# Altium schematic sheet PowerSchem.SchDoc(PowerSchem) (continued)
## Library data of the parts (continued)
C42 = CAP: Ceramic Capacitor, Multilayer, Ceramic, 250V, 5% +Tol, 5% -Tol, C0G, 30ppm/Cel TC, 5.6e-05uF, Surface Mount, 0603; Manufacturer: Knowles; Manufacturer Part Number: QSCP251Q560J1GV001T; Case/Package: 0603; Category: Ceramic Capacitors; Component Kind: Standard; ComponentLink1Description: https://datasheet.octopart.com:443/QSCP251Q560J1GV001T-Johanson-datasheet-187816102.pdf?src-supplier=IHS; ComponentLink1URL: https://datasheet.octopart.com:443/QSCP251Q560J1GV001T-Johanson-datasheet-187816102.pdf?src-supplier=IHS; Country of Origin: USA; Dielectric Material: Ceramic; Export Control Classification Number (ECCN) Code: EAR99; Harmonized Tariff Schedule (HTS) Code: 8532.24.00.20; Height: 760µm; Introduction Date: 2022-02-04; Length: 1.57mm; Library Name: Standard.SchLib; Lifecycle Status: Production; Max Operating Temperature: 125°C; Min Operating Temperature: -55°C; Mounting Technology: Surface Mount; Number of Terminals: 2; Pricing: 1=0.28, 10=0.162, 50=0.1176, 100=0.1038, 500=0.08066, 1000=0.07352 (USD); REACH SVHC: Yes; RoHS: Compliant; Stock: 30631; Supplier 1: DigiKey; Supplier Part Number 1: 712-QSCP251Q560J1GV001TCT-ND; Value: 56p; Voltage Rating (DC): 250V; Width: 810µm
C43 = CAP: 0603 C 15NF Ceramic Multilayer Capacitor, 25 V, +125DEGC, C0G Dielectric, +/-5%; Manufacturer: Yageo Group; Manufacturer Part Number: C0603C153J3GACTU; Dissipation Factor: 0.1%; Temperature Coefficient: 30ppm/°C; Tolerance: 5%; Voltage: 25V; Case Code (Imperial): 0603; Case Code (Metric): 1608; Case/Package: 0603; Category: Ceramic Capacitors; China RoHS: Compliant; Component Kind: Standard; ComponentLink1Description: https://datasheet.octopart.com:443/C0603C153J3GACTU-KEMET-datasheet-178132257.pdf?src-supplier=IHS; ComponentLink1URL: https://datasheet.octopart.com:443/C0603C153J3GACTU-KEMET-datasheet-178132257.pdf?src-supplier=IHS; ComponentLink2Description: https://datasheet.octopart.com:443/C0603C153J3GAC7867-KEMET-datasheet-10540709.pdf?src-supplier=IHS; ComponentLink2URL: https://datasheet.octopart.com:443/C0603C153J3GAC7867-KEMET-datasheet-10540709.pdf?src-supplier=IHS; ComponentLink3Description: https://datasheet.octopart.com:443/C0603C153J3GACTU-KEMET-datasheet-137357764.pdf?src-supplier=Upverter; ComponentLink3URL: https://datasheet.octopart.com:443/C0603C153J3GACTU-KEMET-datasheet-137357764.pdf?src-supplier=Upverter; ComponentLink4Description: https://datasheet.octopart.com:443/C0603C153J3GACTU-KEMET-datasheet-68331921.pdf?src-supplier=Farnell; ComponentLink4URL: https://datasheet.octopart.com:443/C0603C153J3GACTU-KEMET-datasheet-68331921.pdf?src-supplier=Farnell; ComponentLink5Description: https://datasheet.octopart.com:443/C0603C153J3GACTU-KEMET-datasheet-102648314.pdf?src-supplier=Farnell; ComponentLink5URL: https://datasheet.octopart.com:443/C0603C153J3GACTU-KEMET-datasheet-102648314.pdf?src-supplier=Farnell; ComponentLink6Description: https://datasheet.octopart.com:443/C0603C153J3GACTU-Kemet-datasheet-5308203.pdf?src-supplier=Farnell; ComponentLink6URL: https://datasheet.octopart.com:443/C0603C153J3GACTU-Kemet-datasheet-5308203.pdf?src-supplier=Farnell; ComponentLink7Description: https://datasheet.octopart.com:443/C0603C153J3GACTU-KEMET-datasheet-176289261.pdf?src-supplier=element14+APAC; ComponentLink7URL: https://datasheet.octopart.com:443/C0603C153J3GACTU-KEMET-datasheet-176289261.pdf?src-supplier=element14+APAC; ComponentLink8Description: https://datasheet.octopart.com:443/C0603C153J3GACTU-KEMET-datasheet-178087916.pdf?src-supplier=element14+APAC; ComponentLink8URL: https://datasheet.octopart.com:443/C0603C153J3GACTU-KEMET-datasheet-178087916.pdf?src-supplier=element14+APAC; ComponentLink9Description: https://datasheet.octopart.com:443/C0603C153J3GACTU-Kemet-datasheet-66093294.pdf?src-supplier=element14+APAC; ComponentLink9URL: https://datasheet.octopart.com:443/C0603C153J3GACTU-Kemet-datasheet-66093294.pdf?src-supplier=element14+APAC; ComponentLink10Description: https://datasheet.octopart.com:443/C0603C153J3GACTU-Kemet-datasheet-66634713.pdf?src-supplier=element14+APAC; ComponentLink10URL: https://datasheet.octopart.com:443/C0603C153J3GACTU-Kemet-datasheet-66634713.pdf?src-supplier=element14+APAC; ComponentLink11Description: https://datasheet.octopart.com:443/C0603C153J3GACTU-KEMET-datasheet-157246123.pdf?src-supplier=Electro+Sonic; ComponentLink11URL: https://datasheet.octopart.com:443/C0603C153J3GACTU-KEMET-datasheet-157246123.pdf?src-supplier=Electro+Sonic; ComponentLink12Description: https://datasheet.octopart.com:443/C0603C153J3GAC7867-KEMET-datasheet-188512010.pdf?src-supplier=ODG+(Origin+Data+Global); ComponentLink12URL: https://datasheet.octopart.com:443/C0603C153J3GAC7867-KEMET-datasheet-188512010.pdf?src-supplier=ODG+(Origin+Data+Global); ComponentLink13Description: https://datasheet.octopart.com:443/C0603C153J3GACTU-KEMET-datasheet-176142193.pdf?src-supplier=Newark; ComponentLink13URL: https://datasheet.octopart.com:443/C0603C153J3GACTU-KEMET-datasheet-176142193.pdf?src-supplier=Newark; ComponentLink14Description: https://datasheet.octopart.com:443/C0603C153J3GACTU-KEMET-datasheet-101575703.pdf?src-supplier=Newark; ComponentLink14URL: https://datasheet.octopart.com:443/C0603C153J3GACTU-KEMET-datasheet-101575703.pdf?src-supplier=Newark; ComponentLink15Description: https://datasheet.octopart.com:443/C0603C153J3GACTU-Kemet-datasheet-62307647.pdf?src-supplier=Newark; ComponentLink15URL: https://datasheet.octopart.com:443/C0603C153J3GACTU-Kemet-datasheet-62307647.pdf?src-supplier=Newark; ComponentLink16Description: https://datasheet.octopart.com:443/C0603C153J3GACTU-KEMET-datasheet-193515620.pdf?src-supplier=Newark; ComponentLink16URL: https://datasheet.octopart.com:443/C0603C153J3GACTU-KEMET-datasheet-193515620.pdf?src-supplier=Newark; ComponentLink17Description: https://datasheet.octopart.com:443/C0603C153J3GACTU-Kemet-datasheet-67830794.pdf?src-supplier=TME; ComponentLink17URL: https://datasheet.octopart.com:443/C0603C153J3GACTU-Kemet-datasheet-67830794.pdf?src-supplier=TME; ComponentLink18Description: https://datasheet.octopart.com/C0603C153J3GACTU-KEMET-datasheet-84169754.pdf; ComponentLink18URL: https://datasheet.octopart.com/C0603C153J3GACTU-KEMET-datasheet-84169754.pdf; ComponentLink19Description: https://datasheet.octopart.com:443/C0603C153J3GACTU-Kemet-datasheet-60243840.pdf?src-supplier=RS+(Formerly+Allied+Electronics); ComponentLink19URL: https://datasheet.octopart.com:443/C0603C153J3GACTU-Kemet-datasheet-60243840.pdf?src-supplier=RS+(Formerly+Allied+Electronics); ComponentLink20Description: http://sigma.octopart.com:80/conflict_mineral_statement/Yageo-company-3.pdf?src-supplier=Compliance+Statements; ComponentLink20URL: http://sigma.octopart.com:80/conflict_mineral_statement/Yageo-company-3.pdf?src-supplier=Compliance+Statements; ComponentLink21Description: http://sigma.octopart.com:80/rohs_statement/Yageo-company-2.pdf?src-supplier=Compliance+Statements; ComponentLink21URL: http://sigma.octopart.com:80/rohs_statement/Yageo-company-2.pdf?src-supplier=Compliance+Statements; Contact Plating: Tin; Country of Origin: Mexico; Depth: 800µm; Dielectric: C0G; Dielectric Material: Ceramic; Export Control Classification Number (ECCN) Code: EAR99; Harmonized Tariff Schedule (HTS) Code: 8532.24.00.20; Height: 800µm; Introduction Date: 2008-06-06; Lead Free: Lead Free; Length: 1.6mm; Library Name: Standard.SchLib; Lifecycle Status: Production; Material: Ceramic; Max Operating Temperature: 125°C; Min Operating Temperature: -55°C; Mounting Technology: Surface Mount; Number of Terminals: 2; Packaging: Cut Tape; Pins: 2; Pricing: 4000=0.087, 8000=0.084 (USD); REACH SVHC: Yes; RoHS: Compliant; Schedule B: 8532240020, 8532240020|8532240020, 8532240020|8532240020|8532240020, 8532240020|8532240020|8532240020|8532240020; Stock: 31000; Supplier 1: Newark; Supplier Part Number 1: C0603C153J3GACTU; Termination: SMD/SMT; Thickness: 863.6µm; Value: 15n; Voltage Rating: 25V; Voltage Rating (DC): 25V; Width: 800µm
C47 C48 = CAP: Multilayer Ceramic Capacitors Mlcc - Smd/Smt 50V 0.01Uf X7R 0603 10%; Manufacturer: Yageo Group; Manufacturer Part Number: C0603C103K5RACTU; Dissipation Factor: 2.5%; Temperature Coefficient: 30ppm/°C; Case Code (Imperial): 0603; Case/Package: 0603; Category: Ceramic Capacitors; China RoHS: Compliant; Component Kind: Standard; ComponentLink1Description: https://datasheet.octopart.com:443/C0603C103K5RACTU-KEMET-datasheet-178445679.pdf?src-supplier=Farnell; ComponentLink1URL: https://datasheet.octopart.com:443/C0603C103K5RACTU-KEMET-datasheet-178445679.pdf?src-supplier=Farnell; ComponentLink2Description: https://datasheet.octopart.com:443/C0603C103K5RACTU-KEMET-datasheet-137357759.pdf?src-supplier=Farnell; ComponentLink2URL: https://datasheet.octopart.com:443/C0603C103K5RACTU-KEMET-datasheet-137357759.pdf?src-supplier=Farnell; ComponentLink3Description: https://datasheet.octopart.com:443/C0603C103K5RACTU-KEMET-datasheet-149521831.pdf?src-supplier=Farnell; ComponentLink3URL: https://datasheet.octopart.com:443/C0603C103K5RACTU-KEMET-datasheet-149521831.pdf?src-supplier=Farnell; ComponentLink4Description: https://datasheet.octopart.com:443/C0603C103K5RAC-TU-Kemet-datasheet-12608295.pdf?src-supplier=Farnell; ComponentLink4URL: https://datasheet.octopart.com:443/C0603C103K5RAC-TU-Kemet-datasheet-12608295.pdf?src-supplier=Farnell; ComponentLink5Description: https://datasheet.octopart.com:443/C0603C103K5RAC-TU-Kemet-datasheet-11992184.pdf?src-supplier=Farnell; ComponentLink5URL: https://datasheet.octopart.com:443/C0603C103K5RAC-TU-Kemet-datasheet-11992184.pdf?src-supplier=Farnell; ComponentLink6Description: https://datasheet.octopart.com:443/C0603C103K5RAC-TU-Kemet-datasheet-10942409.pdf?src-supplier=Farnell; ComponentLink6URL: https://datasheet.octopart.com:443/C0603C103K5RAC-TU-Kemet-datasheet-10942409.pdf?src-supplier=Farnell; ComponentLink7Description: https://datasheet.octopart.com:443/C0603C103K5RAC-TU-Kemet-datasheet-10404442.pdf?src-supplier=Farnell; ComponentLink7URL: https://datasheet.octopart.com:443/C0603C103K5RAC-TU-Kemet-datasheet-10404442.pdf?src-supplier=Farnell; ComponentLink8Description: https://datasheet.octopart.com:443/C0603C103K5RACTU-KEMET-datasheet-101575646.pdf?src-supplier=Farnell; ComponentLink8URL: https://datasheet.octopart.com:443/C0603C103K5RACTU-KEMET-datasheet-101575646.pdf?src-supplier=Farnell; ComponentLink9Description: https://datasheet.octopart.com:443/C0603C103K5RACTU.-Kemet-datasheet-41232427.pdf?src-supplier=Farnell; ComponentLink9URL: https://datasheet.octopart.com:443/C0603C103K5RACTU.-Kemet-datasheet-41232427.pdf?src-supplier=Farnell; ComponentLink10Description: https://datasheet.octopart.com:443/C0603C103K5RACTU-KEMET-datasheet-176142155.pdf?src-supplier=Farnell; ComponentLink10URL: https://datasheet.octopart.com:443/C0603C103K5RACTU-KEMET-datasheet-176142155.pdf?src-supplier=Farnell; ComponentLink11Description: https://datasheet.octopart.com:443/C0603C103K5RAC-TU-Kemet-datasheet-7833651.pdf?src-supplier=Farnell; ComponentLink11URL: https://datasheet.octopart.com:443/C0603C103K5RAC-TU-Kemet-datasheet-7833651.pdf?src-supplier=Farnell; ComponentLink12Description: https://datasheet.octopart.com:443/C0603C103K5RAC-TU-Kemet-datasheet-7601896.pdf?src-supplier=Farnell; ComponentLink12URL: https://datasheet.octopart.com:443/C0603C103K5RAC-TU-Kemet-datasheet-7601896.pdf?src-supplier=Farnell; ComponentLink13Description: https://datasheet.octopart.com:443/C0603C103K5RAC-TU-Kemet-datasheet-5316294.pdf?src-supplier=Farnell; ComponentLink13URL: https://datasheet.octopart.com:443/C0603C103K5RAC-TU-Kemet-datasheet-5316294.pdf?src-supplier=Farnell; ComponentLink14Description: https://datasheet.octopart.com:443/C0603C103K5RAC-TU-Kemet-datasheet-5314837.pdf?src-supplier=Farnell; ComponentLink14URL: https://datasheet.octopart.com:443/C0603C103K5RAC-TU-Kemet-datasheet-5314837.pdf?src-supplier=Farnell; ComponentLink15Description: https://datasheet.octopart.com:443/C0603C103K5RAC-TU-Kemet-datasheet-8092359.pdf?src-supplier=Farnell; ComponentLink15URL: https://datasheet.octopart.com:443/C0603C103K5RAC-TU-Kemet-datasheet-8092359.pdf?src-supplier=Farnell; ComponentLink16Description: https://datasheet.octopart.com:443/C0603C103K5RACTU-Kemet-datasheet-5314449.pdf?src-supplier=Farnell; ComponentLink16URL: https://datasheet.octopart.com:443/C0603C103K5RACTU-Kemet-datasheet-5314449.pdf?src-supplier=Farnell; ComponentLink17Description: https://datasheet.octopart.com:443/C0603C103K5RAC-TU-Kemet-datasheet-5390537.pdf?src-supplier=Farnell; ComponentLink17URL: https://datasheet.octopart.com:443/C0603C103K5RAC-TU-Kemet-datasheet-5390537.pdf?src-supplier=Farnell; ComponentLink18Description: https://datasheet.octopart.com:443/C0603C103K5RAC-TU-Kemet-datasheet-5314969.pdf?src-supplier=Farnell; ComponentLink18URL: https://datasheet.octopart.com:443/C0603C103K5RAC-TU-Kemet-datasheet-5314969.pdf?src-supplier=Farnell; ComponentLink19Description: https://datasheet.octopart.com:443/C0603C103K5RAC-TU-Kemet-datasheet-5314627.pdf?src-supplier=Farnell; ComponentLink19URL: https://datasheet.octopart.com:443/C0603C103K5RAC-TU-Kemet-datasheet-5314627.pdf?src-supplier=Farnell; ComponentLink20Description: https://datasheet.octopart.com:443/C0603C103K5RAC-TU-Kemet-datasheet-5389132.pdf?src-supplier=Farnell; ComponentLink20URL: https://datasheet.octopart.com:443/C0603C103K5RAC-TU-Kemet-datasheet-5389132.pdf?src-supplier=Farnell; ComponentLink21Description: https://datasheet.octopart.com:443/C0603C103K5RAC-TU-Kemet-datasheet-5313836.pdf?src-supplier=Farnell; ComponentLink21URL: https://datasheet.octopart.com:443/C0603C103K5RAC-TU-Kemet-datasheet-5313836.pdf?src-supplier=Farnell; ComponentLink22Description: https://datasheet.octopart.com:443/C0603C103K5RAC-TU-Kemet-datasheet-8111010.pdf?src-supplier=Farnell; ComponentLink22URL: https://datasheet.octopart.com:443/C0603C103K5RAC-TU-Kemet-datasheet-8111010.pdf?src-supplier=Farnell; ComponentLink23Description: https://datasheet.octopart.com:443/C0603C103K5RAC-TU-Kemet-datasheet-7541751.pdf?src-supplier=Farnell; ComponentLink23URL: https://datasheet.octopart.com:443/C0603C103K5RAC-TU-Kemet-datasheet-7541751.pdf?src-supplier=Farnell; ComponentLink24Description: https://datasheet.octopart.com:443/C0603C103K5RAC-TU-Kemet-datasheet-7627733.pdf?src-supplier=Farnell; ComponentLink24URL: https://datasheet.octopart.com:443/C0603C103K5RAC-TU-Kemet-datasheet-7627733.pdf?src-supplier=Farnell; ComponentLink25Description: https://datasheet.octopart.com:443/C0603C103K5RAC-TU-Kemet-datasheet-5315946.pdf?src-supplier=Farnell; ComponentLink25URL: https://datasheet.octopart.com:443/C0603C103K5RAC-TU-Kemet-datasheet-5315946.pdf?src-supplier=Farnell; ComponentLink26Description: https://datasheet.octopart.com:443/C0603C103K5RAC7867-KEMET-datasheet-182039592.pdf?src-supplier=IHS; ComponentLink26URL: https://datasheet.octopart.com:443/C0603C103K5RAC7867-KEMET-datasheet-182039592.pdf?src-supplier=IHS; ComponentLink27Description: https://datasheet.octopart.com:443/C0603C103K5RACTU-KEMET-datasheet-137357764.pdf?src-supplier=Upverter; ComponentLink27URL: https://datasheet.octopart.com:443/C0603C103K5RACTU-KEMET-datasheet-137357764.pdf?src-supplier=Upverter; ComponentLink28Description: https://datasheet.octopart.com:443/C0603C103K5RACTU-Kemet-datasheet-5313890.pdf?src-supplier=element14; ComponentLink28URL: https://datasheet.octopart.com:443/C0603C103K5RACTU-Kemet-datasheet-5313890.pdf?src-supplier=element14; ComponentLink29Description: https://datasheet.octopart.com:443/C0603C103K5RACTU-KEMET-datasheet-157246124.pdf?src-supplier=Electro+Sonic; ComponentLink29URL: https://datasheet.octopart.com:443/C0603C103K5RACTU-KEMET-datasheet-157246124.pdf?src-supplier=Electro+Sonic; ComponentLink30Description: https://datasheet.octopart.com:443/C0603C103K5RACTU-KEMET-datasheet-32036385.pdf?src-supplier=Electro+Sonic; ComponentLink30URL: https://datasheet.octopart.com:443/C0603C103K5RACTU-KEMET-datasheet-32036385.pdf?src-supplier=Electro+Sonic; ComponentLink31Description: https://datasheet.octopart.com:443/C0603C103K5RAC7867-KEMET-datasheet-188512054.pdf?src-supplier=ODG+(Origin+Data+Global); ComponentLink31URL: https://datasheet.octopart.com:443/C0603C103K5RAC7867-KEMET-datasheet-188512054.pdf?src-supplier=ODG+(Origin+Data+Global); ComponentLink32Description: https://datasheet.octopart.com:443/C0603C103K5RACTU-KEMET-datasheet-176142164.pdf?src-supplier=Newark; ComponentLink32URL: https://datasheet.octopart.com:443/C0603C103K5RACTU-KEMET-datasheet-176142164.pdf?src-supplier=Newark; ComponentLink33Description: https://datasheet.octopart.com:443/C0603C103K5RACTU-Kemet-datasheet-27203261.pdf?src-supplier=Newark; ComponentLink33URL: https://datasheet.octopart.com:443/C0603C103K5RACTU-Kemet-datasheet-27203261.pdf?src-supplier=Newark; ComponentLink34Description: https://datasheet.octopart.com:443/C0603C103K5RACTU-KEMET-datasheet-164512540.pdf?src-supplier=TME; ComponentLink34URL: https://datasheet.octopart.com:443/C0603C103K5RACTU-KEMET-datasheet-164512540.pdf?src-supplier=TME; ComponentLink35Description: https://datasheet.octopart.com:443/C0603C103K5RACTU-KEMET-datasheet-81639432.pdf?src-supplier=TME; ComponentLink35URL: https://datasheet.octopart.com:443/C0603C103K5RACTU-KEMET-datasheet-81639432.pdf?src-supplier=TME; ComponentLink36Description: https://datasheet.octopart.com:443/C0603C103K5RACTU-KEMET-datasheet-68331906.pdf?src-supplier=TME; ComponentLink36URL: https://datasheet.octopart.com:443/C0603C103K5RACTU-KEMET-datasheet-68331906.pdf?src-supplier=TME; ComponentLink37Description: https://datasheet.octopart.com:443/C0603C103K5RACTU-KEMET-datasheet-110724412.pdf?src-supplier=RS+(Formerly+Allied+Electronics); ComponentLink37URL: https://datasheet.octopart.com:443/C0603C103K5RACTU-KEMET-datasheet-110724412.pdf?src-supplier=RS+(Formerly+Allied+Electronics); ComponentLink38Description: https://datasheet.octopart.com:443/C0603C103K5RACTU-Kemet-datasheet-464.pdf?src-supplier=RS+(Formerly+Allied+Electronics); ComponentLink38URL: https://datasheet.octopart.com:443/C0603C103K5RACTU-Kemet-datasheet-464.pdf?src-supplier=RS+(Formerly+Allied+Electronics); ComponentLink39Description: https://datasheet.octopart.com/C0603C103K5RACTU-KEMET-datasheet-84169754.pdf; ComponentLink39URL: https://datasheet.octopart.com/C0603C103K5RACTU-KEMET-datasheet-84169754.pdf; ComponentLink40Description: https://datasheet.octopart.com:443/C0603C103K5RACTU-Kemet-datasheet-22084244.pdf?src-supplier=element14+APAC; ComponentLink40URL: https://datasheet.octopart.com:443/C0603C103K5RACTU-Kemet-datasheet-22084244.pdf?src-supplier=element14+APAC; ComponentLink41Description: https://datasheet.octopart.com:443/C0603C103K5RACTU-Kemet-datasheet-11898999.pdf?src-supplier=Arrow+Electronics; ComponentLink41URL: https://datasheet.octopart.com:443/C0603C103K5RACTU-Kemet-datasheet-11898999.pdf?src-supplier=Arrow+Electronics; ComponentLink42Description: https://datasheet.octopart.com:443/C0603C103K5RAC7867-KEMET-datasheet-164857143.pdf?src-supplier=Burklin+Elektronik; ComponentLink42URL: https://datasheet.octopart.com:443/C0603C103K5RAC7867-KEMET-datasheet-164857143.pdf?src-supplier=Burklin+Elektronik; ComponentLink43Description: http://sigma.octopart.com:80/conflict_mineral_statement/Yageo-company-3.pdf?src-supplier=Compliance+Statements; ComponentLink43URL: http://sigma.octopart.com:80/conflict_mineral_statement/Yageo-company-3.pdf?src-supplier=Compliance+Statements; ComponentLink44Description: http://sigma.octopart.com:80/rohs_statement/Yageo-company-2.pdf?src-supplier=Compliance+Statements; ComponentLink44URL: http://sigma.octopart.com:80/rohs_statement/Yageo-company-2.pdf?src-supplier=Compliance+Statements; Contact Plating: Tin; Country of Origin: Mainland China, Mexico; Depth: 800µm; Dielectric: X7R; Dielectric Material: Ceramic; Export Control Classification Number (ECCN) Code: EAR99; Harmonized Tariff Schedule (HTS) Code: 8532.24.00.20; Height: 800µm; Introduction Date: 2011-07-27; Lead Free: Lead Free; Lead Pitch: 700µm; Length: 1.6mm; Library Name: Passives.SchLib; Lifecycle Status: Production; Material: Ceramic; Max Operating Temperature: 125°C; Min Operating Temperature: -55°C; Mounting Technology: Surface Mount; Number of Terminals: 2; Packaging: Cut Tape; Pins: 2; Pricing: 4000=0.00842, 8000=0.00823, 16000=0.00795, 32000=0.00764, 64000=0.00735 (USD); Radiation Hardening: No; REACH SVHC: Yes; RoHS: Compliant; Schedule B: 8532240020, 8532240020|8532240020, 8532240020|8532240020|8532240020, 8532240020|8532240020|8532240020|8532240020; Stock: 2208000; Supplier 1: Avnet; Supplier Part Number 1: C0603C103K5RACTU; Termination: SMD/SMT; Thickness: 863.6µm; Tolerance: 10%; Value: 10n; Voltage: 50V; Voltage Rating: 50V; Voltage Rating (DC): 50V; Width: 800µm
D10 = Zenner: ESD9X5.0ST5G Series 6.2 V 65 pF TVS Diode for ESD Protection - SOD-923; Manufacturer: onsemi; Manufacturer Lifecycle Status: ACTIVE; Breakdown Voltage: 6.2V; Clamping Voltage: 12.3V; Direction: Unidirectional; Element Configuration: Single; ESD Protection: Yes; Forward Voltage: 1.1V; Leakage Current: 1µA; Max Junction Temperature (Tj): 150°C; Max Power Dissipation: 150mW; Max Surge Current: 8.7A; Number of Channels: 1; Operating Supply Voltage: 5V; Peak Pulse Power: 107W; Power Line Protection: No; Test Current: 1mA; Case/Package: SOD-923-2; Category: TVS Diodes; China RoHS: Compliant; Component Kind: Standard; ComponentLink1Description: https://datasheet.octopart.com:443/ESD9X5.0ST5G-ON-Semiconductor-datasheet-8330357.pdf?src-supplier=Upverter; ComponentLink1URL: https://datasheet.octopart.com:443/ESD9X5.0ST5G-ON-Semiconductor-datasheet-8330357.pdf?src-supplier=Upverter; ComponentLink2Description: https://datasheet.octopart.com:443/ESD9X5.0ST5G-onsemi-datasheet-168568609.pdf?src-supplier=Upverter; ComponentLink2URL: https://datasheet.octopart.com:443/ESD9X5.0ST5G-onsemi-datasheet-168568609.pdf?src-supplier=Upverter; ComponentLink3Description: https://datasheet.octopart.com:443/ESD9X5.0ST5G-ON-Semiconductor-datasheet-139009868.pdf?src-supplier=Electro+Sonic; ComponentLink3URL: https://datasheet.octopart.com:443/ESD9X5.0ST5G-ON-Semiconductor-datasheet-139009868.pdf?src-supplier=Electro+Sonic; ComponentLink4Description: https://datasheet.octopart.com:443/ESD9X5.0ST5G-onsemi-datasheet-180958921.pdf?src-supplier=IHS; ComponentLink4URL: https://datasheet.octopart.com:443/ESD9X5.0ST5G-onsemi-datasheet-180958921.pdf?src-supplier=IHS; ComponentLink5Description: https://datasheet.octopart.com:443/ESD9X5.0ST5G-ON-Semiconductor-datasheet-12513463.pdf?src-supplier=Newark; ComponentLink5URL: https://datasheet.octopart.com:443/ESD9X5.0ST5G-ON-Semiconductor-datasheet-12513463.pdf?src-supplier=Newark; ComponentLink6Description: https://datasheet.octopart.com:443/ESD9X5.0ST5G-onsemi-datasheet-189128587.pdf?src-supplier=Master+Electronics; ComponentLink6URL: https://datasheet.octopart.com:443/ESD9X5.0ST5G-onsemi-datasheet-189128587.pdf?src-supplier=Master+Electronics; ComponentLink7Description: https://datasheet.octopart.com:443/ESD9X5.0ST5G-onsemi-datasheet-164667579.pdf?src-supplier=Newchip+Electronics+Limited; ComponentLink7URL: https://datasheet.octopart.com:443/ESD9X5.0ST5G-onsemi-datasheet-164667579.pdf?src-supplier=Newchip+Electronics+Limited; ComponentLink8Description: https://datasheet.octopart.com:443/ESD9X5.0ST5G-ON-Semiconductor-datasheet-14047635.pdf?src-supplier=iiiC; ComponentLink8URL: https://datasheet.octopart.com:443/ESD9X5.0ST5G-ON-Semiconductor-datasheet-14047635.pdf?src-supplier=iiiC; ComponentLink9Description: http://sigma.octopart.com:80/15540786/rohs_certificate/ON-Semiconductor-ESD9X5.0ST5G.pdf?src-supplier=Compliance+Statements; ComponentLink9URL: http://sigma.octopart.com:80/15540786/rohs_certificate/ON-Semiconductor-ESD9X5.0ST5G.pdf?src-supplier=Compliance+Statements; ComponentLink10Description: http://sigma.octopart.com:80/15676178/materials_sheet/ON-Semiconductor-ESD9X5.0ST5G.csv?src-supplier=Compliance+Statements; ComponentLink10URL: http://sigma.octopart.com:80/15676178/materials_sheet/ON-Semiconductor-ESD9X5.0ST5G.csv?src-supplier=Compliance+Statements; ComponentLink11Description: http://sigma.octopart.com:80/150607609/rohs_statement/ON-Semiconductor-ESD9X5.0ST5G.pdf?src-supplier=Compliance+Statements; ComponentLink11URL: http://sigma.octopart.com:80/150607609/rohs_statement/ON-Semiconductor-ESD9X5.0ST5G.pdf?src-supplier=Compliance+Statements; ComponentLink12Description: http://sigma.octopart.com:80/reach_statement/ON-Semiconductor-company-79.pdf?src-supplier=Compliance+Statements; ComponentLink12URL: http://sigma.octopart.com:80/reach_statement/ON-Semiconductor-company-79.pdf?src-supplier=Compliance+Statements; ComponentLink13Description: https://octopart.com:443/opatz8j6/c1?t=U4xq248CjZk49aqVlr5k0EZ1S20O7hXZC5x3rHWSH-sYxZ_igJwI8JXMjxjum5nk7b2LPFvSUdjhtWeLCCyF45HKJ3gQrOw2ICCLdwIt4F_CeAv9k9h4bPg01xsOqGsl4_WPHk2-sHZisKbPVHvESszEH42MI_EGcnWZn37s8EDrb9R9sRHl5QrF73OS571ory3wTq49jEdwCvmsvOyGlnMZqdznSgUnAulqt8UeVTtCWi1h0LjaV3_jenB72zXObhJcrUkKGX87J72uvMIaqlf2gdrzqerpU4qgDLbtRMl999sH-387FE5OvjKwPt4qFBwoXQia9kWdxdoPHguyJMxxDQ6CZJ78I1Jz7wf_&src-supplier=Compliance+Statements; ComponentLink13URL: https://octopart.com:443/opatz8j6/c1?t=U4xq248CjZk49aqVlr5k0EZ1S20O7hXZC5x3rHWSH-sYxZ_igJwI8JXMjxjum5nk7b2LPFvSUdjhtWeLCCyF45HKJ3gQrOw2ICCLdwIt4F_CeAv9k9h4bPg01xsOqGsl4_WPHk2-sHZisKbPVHvESszEH42MI_EGcnWZn37s8EDrb9R9sRHl5QrF73OS571ory3wTq49jEdwCvmsvOyGlnMZqdznSgUnAulqt8UeVTtCWi1h0LjaV3_jenB72zXObhJcrUkKGX87J72uvMIaqlf2gdrzqerpU4qgDLbtRMl999sH-387FE5OvjKwPt4qFBwoXQia9kWdxdoPHguyJMxxDQ6CZJ78I1Jz7wf_&src-supplier=Compliance+Statements; ComponentLink14Description: https://octopart.com:443/opatz8j6/c1?t=3pWjA3sury-vXDZ6jn5EtAlCMoxYtX1AYxU0u-L05MRKzzY3i90hWYZqBJ7nD0FpcxQU6NVvWSfrj8spDvkDVcv6hBGs2FKejoF387FKwRHUXxrKuAp20zxQf1xviP5DOSGH0oDp6nciOnSgABqDgBRfE1IdXVb1HgMibrXHg5ILIw0i4bTNvinhI9nbqtNHG7c2z1LCxAmzkrm1UggRYQnaU9WfKM8lDyf7V15dE3D-xK99Oqq1XK1trMaZ5tCK2HDTe7-XsgP0FTthRAXuAn3xjXwE5sHZ4Tfbg-hc08R_QhUItco4PPzxqp_Ni38_sf5Aw8nlbFeh5fp6Pc1W3BfPLWy2YwzTcFmoPFUupg&src-supplier=Compliance+Statements; ComponentLink14URL: https://octopart.com:443/opatz8j6/c1?t=3pWjA3sury-vXDZ6jn5EtAlCMoxYtX1AYxU0u-L05MRKzzY3i90hWYZqBJ7nD0FpcxQU6NVvWSfrj8spDvkDVcv6hBGs2FKejoF387FKwRHUXxrKuAp20zxQf1xviP5DOSGH0oDp6nciOnSgABqDgBRfE1IdXVb1HgMibrXHg5ILIw0i4bTNvinhI9nbqtNHG7c2z1LCxAmzkrm1UggRYQnaU9WfKM8lDyf7V15dE3D-xK99Oqq1XK1trMaZ5tCK2HDTe7-XsgP0FTthRAXuAn3xjXwE5sHZ4Tfbg-hc08R_QhUItco4PPzxqp_Ni38_sf5Aw8nlbFeh5fp6Pc1W3BfPLWy2YwzTcFmoPFUupg&src-supplier=Compliance+Statements; Composition: Zener; Contact Plating: Tin; Depth: 600µm; Halogen Free: Halogen Free; Height: 400µm; Lead Free: Lead Free; Length: 800µm; Library Name: Diodes.SchLib; Lifecycle Status: Production; Manufacturer Part Number: ESD9X5.0ST5G; Max Breakdown Voltage: 6.2V; Max Operating Temperature: 150°C; Max Reverse Leakage Current: 1µA; Min Breakdown Voltage: 6.2V; Min Operating Temperature: -55°C; Number of Circuits: 1; Number of Elements: 1; Number of Lines: 1; Number of Terminals: 2; Number of Unidirectional Channels: 1; Packaging: Tape and Reel; Peak Pulse Current: 8.7A; Peak Reverse Current: 1µA; Pins: 2; Polarity: Unidirectional; Pricing: 8000=0.0268, 24000=0.0262, 32000=0.0261, 80000=0.0255, 120000=0.025 (USD); Radiation Hardening: No; REACH SVHC: Yes; Reverse Breakdown Voltage: 6.2V; Reverse Standoff Voltage: 5V; RoHS: Compliant; Schedule B: 8536300000, 8536300000|8536300000|8536300000|8536300000|8536300000|8536300000|8536300000|8536300000|8536300000|8536300000|8536300000|8536300000|8536300000|8536300000|8536300000|8536300000|8536300000|8536300000|8536300000|8536300000|8536300000|8536300000|8536300000|85; Stock: 200000; Supplier 1: Future Electronics; Supplier Part Number 1: ESD9X5.0ST5G; Termination: SMD/SMT; Value: 65pF; Width: 650µm; Working Voltage: 5V
D11-D13 = LED_Green: LED yellow green 0603 wavelength 567.5~576.5nm light intensity 4.5~28mcd Front Mounting; Manufacturer: Diodes Inc.; Manufacturer Package Identifier: LTST-C193KGKT-5A; Forward Current: 30mA; Forward Voltage: 1.9V; Illumination Color: Green, Yellow; Lens Color: Clear, Colorless; Lens Style: Clear, Colorless, Diffused, Rectangular; Lens Transparency: Clear; Luminous Intensity: 16.25mcd; Number of Circuits: 1; Peak Wavelength: 574nm; Power Dissipation: 50mW; Power Rating: 75mW; Reverse Voltage: 5V; Shape: Rectangular; Test Current: 5mA; Viewing Angle: 130°; Wavelength: 571nm; Case Code (Imperial): 0603; Case Code (Metric): 1608; Case/Package: 0603; Category: LEDs; China RoHS: Compliant; Color: Green; Component Kind: Standard; ComponentLink1Description: https://datasheet.octopart.com:443/LTST-C193KGKT-5A-Lite-On-datasheet-141439008.pdf?src-supplier=ODG+(Origin+Data+Global); ComponentLink1URL: https://datasheet.octopart.com:443/LTST-C193KGKT-5A-Lite-On-datasheet-141439008.pdf?src-supplier=ODG+(Origin+Data+Global); ComponentLink2Description: https://datasheet.octopart.com:443/LTST-C193KGKT-5A-Lite-On-datasheet-86397324.pdf?src-supplier=Upverter; ComponentLink2URL: https://datasheet.octopart.com:443/LTST-C193KGKT-5A-Lite-On-datasheet-86397324.pdf?src-supplier=Upverter; ComponentLink3Description: https://datasheet.octopart.com:443/LTST-C193KGKT-5A-Lite-On-datasheet-12546740.pdf?src-supplier=_legacy+Avnet; ComponentLink3URL: https://datasheet.octopart.com:443/LTST-C193KGKT-5A-Lite-On-datasheet-12546740.pdf?src-supplier=_legacy+Avnet; ComponentLink4Description: https://datasheet.octopart.com:443/LTST-C193KGKT-5A-Lite-On-datasheet-165573808.pdf?src-supplier=TME; ComponentLink4URL: https://datasheet.octopart.com:443/LTST-C193KGKT-5A-Lite-On-datasheet-165573808.pdf?src-supplier=TME; ComponentLink5Description: https://datasheet.octopart.com:443/LTST-C193KGKT-5A-Lite-On-datasheet-62340975.pdf?src-supplier=TME; ComponentLink5URL: https://datasheet.octopart.com:443/LTST-C193KGKT-5A-Lite-On-datasheet-62340975.pdf?src-supplier=TME; ComponentLink6Description: https://datasheet.octopart.com:443/LTST-C193KGKT-5A-Lite-On-datasheet-14174969.pdf?src-supplier=iiiC; ComponentLink6URL: https://datasheet.octopart.com:443/LTST-C193KGKT-5A-Lite-On-datasheet-14174969.pdf?src-supplier=iiiC; Country of Origin: Mainland China, Thailand; Depth: 800µm; Dominant Wavelength: 576.5nm; Export Control Classification Number (ECCN) Code: EAR99; Harmonized Tariff Schedule (HTS) Code: 8541.40.20.00; Height: 350µm; Introduction Date: 2007-03-15; Lead Free: Lead Free; Length: 1.6mm; Library Name: Standard.SchLib; Lifecycle Status: Production; Manufacturer Part Number: LTST-C193KGKT-5A; Max Operating Temperature: 85°C; Min Operating Temperature: -55°C; Mounting Technology: Surface Mount; Number of Elements: 1; Number of LEDs: 1; Number of Terminals: 2; Pins: 2; Pricing: 5000=0.03977, 10000=0.03887, 20000=0.03797, 40000=0.03708, 80000=0.03618 (USD); Radiation Hardening: No; REACH SVHC: Yes; RoHS: Compliant; Schedule B: 8541402000, 8541402000|8541402000|8541402000|8541402000|8541402000|8541402000|8541402000|8541402000|8541402000|8541402000|8541402000|8541402000|8541402000|8541402000|8541402000|8541402000|8541402000|8541402000|8541402000|8541402000|8541402000|8541402000|8541402000|85; Stock: 95000; Supplier 1: Avnet; Supplier Part Number 1: LTST-C193KGKT-5A; Terminal Pitch: 1.5mm; Width: 800µm
J5 = 2POS: Board Connector, 2 Contact(s), 1 Row(s), Male, Straight, Solder Terminal; Manufacturer: JST; Manufacturer Part Number: B2B-XH-A; Contact Resistance: 20mΩ; Current Rating: 3A; Insulation Resistance: 1GΩ; Number of Positions: 2; Category: Card Edge Connectors; Color: White; Component Kind: Standard; ComponentLink1Description: https://datasheet.octopart.com:443/B2B-XH-A-JST-datasheet-157850277.pdf?src-supplier=IHS; ComponentLink1URL: https://datasheet.octopart.com:443/B2B-XH-A-JST-datasheet-157850277.pdf?src-supplier=IHS; ComponentLink2Description: https://datasheet.octopart.com:443/B2B-XH-A-JST-datasheet-10026044.pdf?src-supplier=TME; ComponentLink2URL: https://datasheet.octopart.com:443/B2B-XH-A-JST-datasheet-10026044.pdf?src-supplier=TME; Contact Material: Brass; Gender: Male; Housing Material: Nylon; Library Name: Connectors.SchLib; Lifecycle Status: Production; Max Operating Temperature: 85°C; Min Operating Temperature: -25°C; Number of Rows: 1; Orientation: Straight; Pins: 2; Pricing: 1=0.1, 10=0.087, 100=0.0742, 500=0.06618, 1000=0.06301, 5000=0.05624, 10000=0.05355 (USD); RoHS: Compliant; Stock: 87864; Supplier 1: DigiKey; Supplier Part Number 1: 455-B2B-XH-A-ND; Terminal Pitch: 2.5mm
L1 = IND_FIXED: Ind Power Shielded Wirewound 8.2uH 20% 100kHz Metal Alloy Powder 8A (6.6 X 6.4 X 5.8mm) T/R; Manufacturer: Bourns; Manufacturer Part Number: SRP6060FA-8R2M; DC Resistance (DCR): 24.8mΩ; Number of Circuits: 1; Saturation Current: 8.5A; Self Resonant Frequency: 14MHz; Category: Fixed Inductors; China RoHS: Compliant; Component Kind: Standard; ComponentLink1Description: https://datasheet.octopart.com:443/SRP6060FA-8R2M-Bourns-datasheet-179985811.pdf?src-supplier=JRH+Electronics; ComponentLink1URL: https://datasheet.octopart.com:443/SRP6060FA-8R2M-Bourns-datasheet-179985811.pdf?src-supplier=JRH+Electronics; ComponentLink2Description: https://datasheet.octopart.com:443/SRP6060FA-8R2M-Bourns-datasheet-110646386.pdf?src-supplier=Master+Electronics; ComponentLink2URL: https://datasheet.octopart.com:443/SRP6060FA-8R2M-Bourns-datasheet-110646386.pdf?src-supplier=Master+Electronics; ComponentLink3Description: https://datasheet.octopart.com:443/SRP6060FA-8R2M-Bourns-datasheet-165058501.pdf?src-supplier=Burklin+Elektronik; ComponentLink3URL: https://datasheet.octopart.com:443/SRP6060FA-8R2M-Bourns-datasheet-165058501.pdf?src-supplier=Burklin+Elektronik; ComponentLink4Description: http://sigma.octopart.com:80/conflict_mineral_statement/Bourns-company-36.pdf?src-supplier=Compliance+Statements; ComponentLink4URL: http://sigma.octopart.com:80/conflict_mineral_statement/Bourns-company-36.pdf?src-supplier=Compliance+Statements; Composition: Wirewound; Contact Plating: Tin; ESR: 24.8mΩ; Export Control Classification Number (ECCN) Code: EAR99; Harmonized Tariff Schedule (HTS) Code: 8504.50.80.00; Height: 5.8mm; Inductance Tolerance: 20%; Introduction Date: 2018-06-07; Length: 6.6mm; Library Name: Standard.SchLib; Lifecycle Status: Production; Max Current Rating: 8A; Max Operating Temperature: 155°C; Min Operating Temperature: -55°C; Number of Terminals: 2; Pricing: 1=1.6, 10=1.38, 25=1.26, 50=1.23, 100=1.2, 250=1.17, 500=1.08 (USD); Ratings: AEC-Q200; REACH SVHC: Yes; RMS Current (Irms): 8A; RoHS: Compliant; Schedule B: 8504500000, 8504500000|8504500000|8504500000|8504500000|8504500000; Shielding: Shielded; Stock: 422; Supplier 1: Avnet; Supplier Part Number 1: 33AK4499; Test Frequency: 100kHz; Tolerance: 20%; Value: 8u2; Width: 6.4mm
Q9 Q10 = PMOS: Single P-Channel 20 V 17 mOhm 116 nC 41 W PowerTrench SMT Mosfet - MLP-3.3x3.3; Manufacturer: onsemi; Manufacturer Lifecycle Status: ACTIVE; Continuous Drain Current (ID): 12A; Drain to Source Breakdown Voltage: -20V; Drain to Source Resistance: 8mΩ; Fall Time: 170ns; Gate to Source Voltage (Vgs): 8V; Input Capacitance: 7.86nF; Max Junction Temperature (Tj): 150°C; Max Power Dissipation: 41W; Min Breakdown Voltage: 20V; Number of Channels: 1; Power Dissipation: 2.3W; Rise Time: 34ns; Threshold Voltage: -500mV; Turn-Off Delay Time: 338ns; Turn-On Delay Time: 15ns; Category: MOSFETs; China RoHS: Compliant; Component Kind: Standard; ComponentLink1Description: https://datasheet.octopart.com:443/FDMC510P-onsemi-datasheet-165728270.pdf?src-supplier=JRH+Electronics; ComponentLink1URL: https://datasheet.octopart.com:443/FDMC510P-onsemi-datasheet-165728270.pdf?src-supplier=JRH+Electronics; ComponentLink2Description: https://datasheet.octopart.com:443/FDMC510P-onsemi-datasheet-180959644.pdf?src-supplier=IHS; ComponentLink2URL: https://datasheet.octopart.com:443/FDMC510P-onsemi-datasheet-180959644.pdf?src-supplier=IHS; ComponentLink3Description: https://datasheet.octopart.com:443/FDMC510P-Fairchild-Semiconductor-datasheet-182289896.pdf?src-supplier=IHS; ComponentLink3URL: https://datasheet.octopart.com:443/FDMC510P-Fairchild-Semiconductor-datasheet-182289896.pdf?src-supplier=IHS; ComponentLink4Description: https://sigma.octopart.com:443/138897669/technical_drawing/onsemi-FDMC510P.pdf?src-supplier=Upverter; ComponentLink4URL: https://sigma.octopart.com:443/138897669/technical_drawing/onsemi-FDMC510P.pdf?src-supplier=Upverter; ComponentLink5Description: https://datasheet.octopart.com:443/FDMC510P-ON-Semiconductor-datasheet-98394445.pdf?src-supplier=Master+Electronics; ComponentLink5URL: https://datasheet.octopart.com:443/FDMC510P-ON-Semiconductor-datasheet-98394445.pdf?src-supplier=Master+Electronics; ComponentLink6Description: https://datasheet.octopart.com:443/FDMC510P-ON-Semiconductor-datasheet-85476435.pdf?src-supplier=onsemi; ComponentLink6URL: https://datasheet.octopart.com:443/FDMC510P-ON-Semiconductor-datasheet-85476435.pdf?src-supplier=onsemi; ComponentLink7Description: https://datasheet.octopart.com:443/FDMC510P-ON-Semiconductor-datasheet-86693097.pdf?src-supplier=onsemi; ComponentLink7URL: https://datasheet.octopart.com:443/FDMC510P-ON-Semiconductor-datasheet-86693097.pdf?src-supplier=onsemi; ComponentLink8Description: https://datasheet.octopart.com:443/FDMC510P-Fairchild-Semiconductor-datasheet-10853929.pdf?src-supplier=element14+APAC; ComponentLink8URL: https://datasheet.octopart.com:443/FDMC510P-Fairchild-Semiconductor-datasheet-10853929.pdf?src-supplier=element14+APAC; ComponentLink9Description: https://datasheet.octopart.com:443/FDMC510P-ON-Semiconductor-datasheet-86688170.pdf?src-supplier=element14+APAC; ComponentLink9URL: https://datasheet.octopart.com:443/FDMC510P-ON-Semiconductor-datasheet-86688170.pdf?src-supplier=element14+APAC; ComponentLink10Description: https://datasheet.octopart.com:443/FDMC510P-Fairchild-Semiconductor-datasheet-33026367.pdf?src-supplier=element14+APAC; ComponentLink10URL: https://datasheet.octopart.com:443/FDMC510P-Fairchild-Semiconductor-datasheet-33026367.pdf?src-supplier=element14+APAC; ComponentLink11Description: https://datasheet.octopart.com:443/FDMC510P-onsemi-datasheet-181958840.pdf?src-supplier=Newark; ComponentLink11URL: https://datasheet.octopart.com:443/FDMC510P-onsemi-datasheet-181958840.pdf?src-supplier=Newark; ComponentLink12Description: https://datasheet.octopart.com:443/FDMC510P-Fairchild-Semiconductor-datasheet-21199293.pdf?src-supplier=Newark; ComponentLink12URL: https://datasheet.octopart.com:443/FDMC510P-Fairchild-Semiconductor-datasheet-21199293.pdf?src-supplier=Newark; ComponentLink13Description: https://datasheet.octopart.com:443/FDMC510P-Fairchild-Semiconductor-datasheet-32852807.pdf?src-supplier=Newark; ComponentLink13URL: https://datasheet.octopart.com:443/FDMC510P-Fairchild-Semiconductor-datasheet-32852807.pdf?src-supplier=Newark; ComponentLink14Description: https://datasheet.octopart.com:443/FDMC510P-ON-Semiconductor-datasheet-33132503.pdf?src-supplier=Fairchild+Semiconductor; ComponentLink14URL: https://datasheet.octopart.com:443/FDMC510P-ON-Semiconductor-datasheet-33132503.pdf?src-supplier=Fairchild+Semiconductor; ComponentLink15Description: https://sigma.octopart.com:443/33130028/technical_drawing/Fairchild-Semiconductor-FDMC510P.pdf?src-supplier=Fairchild+Semiconductor; ComponentLink15URL: https://sigma.octopart.com:443/33130028/technical_drawing/Fairchild-Semiconductor-FDMC510P.pdf?src-supplier=Fairchild+Semiconductor; ComponentLink16Description: https://sigma.octopart.com:443/17347435/technical_drawing/Fairchild-Semiconductor-FDMC510P.pdf?src-supplier=Fairchild+Semiconductor; ComponentLink16URL: https://sigma.octopart.com:443/17347435/technical_drawing/Fairchild-Semiconductor-FDMC510P.pdf?src-supplier=Fairchild+Semiconductor; ComponentLink17Description: https://datasheet.octopart.com:443/FDMC510P-Fairchild-Semiconductor-datasheet-31665617.pdf?src-supplier=Farnell; ComponentLink17URL: https://datasheet.octopart.com:443/FDMC510P-Fairchild-Semiconductor-datasheet-31665617.pdf?src-supplier=Farnell; ComponentLink18Description: https://datasheet.octopart.com:443/FDMC510P-Fairchild-Semiconductor-datasheet-11793458.pdf?src-supplier=Arrow+Electronics; ComponentLink18URL: https://datasheet.octopart.com:443/FDMC510P-Fairchild-Semiconductor-datasheet-11793458.pdf?src-supplier=Arrow+Electronics; ComponentLink19Description: https://datasheet.octopart.com:443/FDMC510P-ON-Semiconductor-datasheet-130079718.pdf?src-supplier=TME; ComponentLink19URL: https://datasheet.octopart.com:443/FDMC510P-ON-Semiconductor-datasheet-130079718.pdf?src-supplier=TME; ComponentLink20Description: https://datasheet.octopart.com:443/FDMC510P-Fairchild-Semiconductor-datasheet-14042252.pdf?src-supplier=iiiC; ComponentLink20URL: https://datasheet.octopart.com:443/FDMC510P-Fairchild-Semiconductor-datasheet-14042252.pdf?src-supplier=iiiC; ComponentLink21Description: http://sigma.octopart.com:80/150608879/rohs_statement/ON-Semiconductor-FDMC510P.pdf?src-supplier=Compliance+Statements; ComponentLink21URL: http://sigma.octopart.com:80/150608879/rohs_statement/ON-Semiconductor-FDMC510P.pdf?src-supplier=Compliance+Statements; ComponentLink22Description: http://sigma.octopart.com:80/17347465/reach_statement/Fairchild-Semiconductor-FDMC510P.pdf?src-supplier=Compliance+Statements; ComponentLink22URL: http://sigma.octopart.com:80/17347465/reach_statement/Fairchild-Semiconductor-FDMC510P.pdf?src-supplier=Compliance+Statements; ComponentLink23Description: http://sigma.octopart.com:80/17347469/materials_sheet/Fairchild-Semiconductor-FDMC510P.pdf?src-supplier=Compliance+Statements; ComponentLink23URL: http://sigma.octopart.com:80/17347469/materials_sheet/Fairchild-Semiconductor-FDMC510P.pdf?src-supplier=Compliance+Statements; ComponentLink24Description: http://sigma.octopart.com:80/33132635/reach_statement/Fairchild-Semiconductor-FDMC510P.pdf?src-supplier=Compliance+Statements; ComponentLink24URL: http://sigma.octopart.com:80/33132635/reach_statement/Fairchild-Semiconductor-FDMC510P.pdf?src-supplier=Compliance+Statements; ComponentLink25Description: http://sigma.octopart.com:80/33132647/materials_sheet/Fairchild-Semiconductor-FDMC510P.pdf?src-supplier=Compliance+Statements; ComponentLink25URL: http://sigma.octopart.com:80/33132647/materials_sheet/Fairchild-Semiconductor-FDMC510P.pdf?src-supplier=Compliance+Statements; ComponentLink26Description: http://sigma.octopart.com:80/reach_statement/ON-Semiconductor-company-79.pdf?src-supplier=Compliance+Statements; ComponentLink26URL: http://sigma.octopart.com:80/reach_statement/ON-Semiconductor-company-79.pdf?src-supplier=Compliance+Statements; ComponentLink27Description: http://sigma.octopart.com:80/reach_statement/Fairchild-Semiconductor-company-9.pdf?src-supplier=Compliance+Statements; ComponentLink27URL: http://sigma.octopart.com:80/reach_statement/Fairchild-Semiconductor-company-9.pdf?src-supplier=Compliance+Statements; ComponentLink28Description: http://sigma.octopart.com:80/rohs_statement/Fairchild-Semiconductor-company-10.pdf?src-supplier=Compliance+Statements; ComponentLink28URL: http://sigma.octopart.com:80/rohs_statement/Fairchild-Semiconductor-company-10.pdf?src-supplier=Compliance+Statements; ComponentLink29Description: http://sigma.octopart.com:80/rohs_statement/Fairchild-Semiconductor-company-11.pdf?src-supplier=Compliance+Statements; ComponentLink29URL: http://sigma.octopart.com:80/rohs_statement/Fairchild-Semiconductor-company-11.pdf?src-supplier=Compliance+Statements; ComponentLink30Description: https://octopart.com:443/opatz8j6/c1?t=dVssBGCTMjMDuZ8LzfI9h-sCjmCv5fC98FaG_P8I69eWOln85ap1aE-Ca2bz-RAyN2ZXqR6przDzMpCE58kh4AJDHYDyR3EpiomfbbDlH4jXtrkNWaDVizcOiV7jmULHPFoYVJAH90Rx5QNsievqdckXrXmLYAFVonklUYXppuEPic276FdRbjc2zYru_rs1cSu4-6y02IakjByRH9wH7ES2BWSk7eA1NwGWHKQ1bgEFufbeIPnBRlFJ2r5lIWj5r9jzPV4HLpWTYrqG4y-Gwam281sC2yDMtqQo1L_Ous2VjI3XkGPB-LvVfIQiWMMSNC83OfJzuEA_eoibmu3f00fgEoipcTa800Bljw&src-supplier=Compliance+Statements; ComponentLink30URL: https://octopart.com:443/opatz8j6/c1?t=dVssBGCTMjMDuZ8LzfI9h-sCjmCv5fC98FaG_P8I69eWOln85ap1aE-Ca2bz-RAyN2ZXqR6przDzMpCE58kh4AJDHYDyR3EpiomfbbDlH4jXtrkNWaDVizcOiV7jmULHPFoYVJAH90Rx5QNsievqdckXrXmLYAFVonklUYXppuEPic276FdRbjc2zYru_rs1cSu4-6y02IakjByRH9wH7ES2BWSk7eA1NwGWHKQ1bgEFufbeIPnBRlFJ2r5lIWj5r9jzPV4HLpWTYrqG4y-Gwam281sC2yDMtqQo1L_Ous2VjI3XkGPB-LvVfIQiWMMSNC83OfJzuEA_eoibmu3f00fgEoipcTa800Bljw&src-supplier=Compliance+Statements; ComponentLink31Description: https://octopart.com:443/opatz8j6/c1?t=ur27FYyO6V6hX1TgMYtmm8OD-P2le7yUFyviZdag842a6RB6B76-HyNzzxTkr3DykXScWdfYvd_d4AuEORiF2Eg5UPIMXJzw-g1ysG48Pa3n2ew7j1Jol16yAbQYlqZfOOVG36Xa2ealcgWEbMkHsy38FXKcIwpMWyneeip2g_VuQ4pe9Hu33BoPR6pAOFWuZDzNMrc4ZUXwNbDIilaAB7qhgoqT1IlrvMfexiSRB7D8_MN69XK-sqE8U5E0iED-b6_M4yP2hG4xxVdhUblIYtUmVsbOoEUCM3MC6YkG10UWi5iEcPtPDOKVNw0cpfVpxKYIrSRS-220T8_vfQM613JpenGVWuGw0El1&src-supplier=Compliance+Statements; ComponentLink31URL: https://octopart.com:443/opatz8j6/c1?t=ur27FYyO6V6hX1TgMYtmm8OD-P2le7yUFyviZdag842a6RB6B76-HyNzzxTkr3DykXScWdfYvd_d4AuEORiF2Eg5UPIMXJzw-g1ysG48Pa3n2ew7j1Jol16yAbQYlqZfOOVG36Xa2ealcgWEbMkHsy38FXKcIwpMWyneeip2g_VuQ4pe9Hu33BoPR6pAOFWuZDzNMrc4ZUXwNbDIilaAB7qhgoqT1IlrvMfexiSRB7D8_MN69XK-sqE8U5E0iED-b6_M4yP2hG4xxVdhUblIYtUmVsbOoEUCM3MC6YkG10UWi5iEcPtPDOKVNw0cpfVpxKYIrSRS-220T8_vfQM613JpenGVWuGw0El1&src-supplier=Compliance+Statements; ComponentLink32Description: https://octopart.com:443/opatz8j6/c1?t=RML8NUxsOD3zxQbLCG8hisxkZIMWN814d7TbImpILMRVzn674V32XSskTU4OpmrXR_F4c8XdCQhWM49aP13ECACXcxqgWHE-E8PlMICW5HechuJMk2pSs0e9rMmYu0YD-EiUNcRS0SwVh-bt5aJmjImXp9rfv5BjJi5oB7pKW6ijneoujgUEyPkNEhjf8nC2laKbe16OQmqFg_QBC8wyWOOSBmsIk6z1ll_is2cHUHx9HwPKEn_pOxfF08i0Zq1-2eWXrF4Fn-y5i3i5XSXygnw-VVhNUAEKeHZUfp77q22Ac852uuosVrhPrj7IIUecCxlsDZn6FyzrV2cOLnTUKkxkBaPb3k2QDqjkJg&src-supplier=Compliance+Statements; ComponentLink32URL: https://octopart.com:443/opatz8j6/c1?t=RML8NUxsOD3zxQbLCG8hisxkZIMWN814d7TbImpILMRVzn674V32XSskTU4OpmrXR_F4c8XdCQhWM49aP13ECACXcxqgWHE-E8PlMICW5HechuJMk2pSs0e9rMmYu0YD-EiUNcRS0SwVh-bt5aJmjImXp9rfv5BjJi5oB7pKW6ijneoujgUEyPkNEhjf8nC2laKbe16OQmqFg_QBC8wyWOOSBmsIk6z1ll_is2cHUHx9HwPKEn_pOxfF08i0Zq1-2eWXrF4Fn-y5i3i5XSXygnw-VVhNUAEKeHZUfp77q22Ac852uuosVrhPrj7IIUecCxlsDZn6FyzrV2cOLnTUKkxkBaPb3k2QDqjkJg&src-supplier=Compliance+Statements; ComponentLink33Description: https://octopart.com:443/opatz8j6/c1?t=ufmlkAmUS6MZ-17ePBarHbc93ydNq8eGZQ0uTxoTrkmQ1MRSwF9KwTFjFjAgo9HcFrtzUSnf1P65j3Lz7eGF6jydxYNGOEIsCBDujU0FsXFPnBJbOTeyY6oOK-w7douSgfiJmsVPw2G3MJ_qKT8i5rYQ4u-soB1E1yDKj1eKh3LO1RwFyk7iXLS9VOkvFPCESk-9Ns8-OSraXB-xNepBnO_lfd9606VBexn1tXLvRynW--i4ax44CvNnqKbAZxH82NP1VAut5zwmhlO-hYedaCK05bTQ7OkheYpxIzA2mC7ELajepPR_fPo26NaJh9t4Sgtxl9-c4nO_U8dely3pgMVGsf9i0vV64Hwf&src-supplier=Compliance+Statements; ComponentLink33URL: https://octopart.com:443/opatz8j6/c1?t=ufmlkAmUS6MZ-17ePBarHbc93ydNq8eGZQ0uTxoTrkmQ1MRSwF9KwTFjFjAgo9HcFrtzUSnf1P65j3Lz7eGF6jydxYNGOEIsCBDujU0FsXFPnBJbOTeyY6oOK-w7douSgfiJmsVPw2G3MJ_qKT8i5rYQ4u-soB1E1yDKj1eKh3LO1RwFyk7iXLS9VOkvFPCESk-9Ns8-OSraXB-xNepBnO_lfd9606VBexn1tXLvRynW--i4ax44CvNnqKbAZxH82NP1VAut5zwmhlO-hYedaCK05bTQ7OkheYpxIzA2mC7ELajepPR_fPo26NaJh9t4Sgtxl9-c4nO_U8dely3pgMVGsf9i0vV64Hwf&src-supplier=Compliance+Statements; Contact Plating: Gold; Country of Origin: Thailand; Drain to Source Voltage (Vdss): -20V; Element Configuration: Single; Export Control Classification Number (ECCN) Code: EAR99; Height: 800µm; Introduction Date: 2010-05-19; Length: 3.3mm; Library Name: Diodes.SchLib; Lifecycle Status: Production; Manufacturer Part Number: FDMC510P; Max Operating Temperature: 150°C; Min Operating Temperature: -55°C; Mounting Technology: Surface Mount; Number of Elements: 1; Number of Terminals: 5; Pins: 8; Pricing: 5=2.73, 10=1.88, 25=1.69, 50=1.49, 100=1.3, 250=1.19, 500=1.08, 1000=1.05 (USD); Radiation Hardening: No; Rds On Max: 8mΩ; REACH SVHC: Yes; RoHS: Compliant; Schedule B: 8541290080, 8541290080|8541290080|8541290080|8541290080|8541290080; Stock: 2627; Supplier: Newark; Supplier 1: Newark; Supplier Part Number: 55T6319; Supplier Part Number 1: FDMC510P; Weight: 165.33333mg; Width: 3.3mm
R46 = RES: GENERAL PURPOSE CHIP RESISTOR Metal Glaze/thick Film, 0.1W, 0ohm, Surface Mount, 0603; Manufacturer: Yageo Group; Manufacturer Part Number: RC0603JR-070RL; Power Rating: 100mW; Temperature Coefficient: 200ppm/°C; Tolerance: 1%; Voltage Rating: 50V; Case Code (Imperial): 0603; Case/Package: 0603; Category: Chip SMD Resistors; China RoHS: Non-Compliant; Component Kind: Standard; ComponentLink1Description: https://datasheet.octopart.com:443/RC0603JR-070RL-Yageo-datasheet-182189538.pdf?src-supplier=IHS; ComponentLink1URL: https://datasheet.octopart.com:443/RC0603JR-070RL-Yageo-datasheet-182189538.pdf?src-supplier=IHS; ComponentLink2Description: https://datasheet.octopart.com:443/RC0603JR-070RL-Yageo-datasheet-8328172.pdf?src-supplier=element14+APAC; ComponentLink2URL: https://datasheet.octopart.com:443/RC0603JR-070RL-Yageo-datasheet-8328172.pdf?src-supplier=element14+APAC; ComponentLink3Description: https://datasheet.octopart.com:443/RC0603JR-070RL-Yageo-datasheet-17842380.pdf?src-supplier=element14+APAC; ComponentLink3URL: https://datasheet.octopart.com:443/RC0603JR-070RL-Yageo-datasheet-17842380.pdf?src-supplier=element14+APAC; ComponentLink4Description: https://datasheet.octopart.com:443/RC0603JR-070RL-Yageo-Group-datasheet-190305687.pdf?src-supplier=Newark; ComponentLink4URL: https://datasheet.octopart.com:443/RC0603JR-070RL-Yageo-Group-datasheet-190305687.pdf?src-supplier=Newark; ComponentLink5Description: https://datasheet.octopart.com:443/RC0603JR-070RL-Yageo-datasheet-12596169.pdf?src-supplier=Newark; ComponentLink5URL: https://datasheet.octopart.com:443/RC0603JR-070RL-Yageo-datasheet-12596169.pdf?src-supplier=Newark; ComponentLink6Description: https://datasheet.octopart.com:443/RC0603JR-070RL-Yageo-datasheet-34211833.pdf?src-supplier=Newark; ComponentLink6URL: https://datasheet.octopart.com:443/RC0603JR-070RL-Yageo-datasheet-34211833.pdf?src-supplier=Newark; ComponentLink7Description: https://datasheet.octopart.com:443/RC0603JR-070RL-Yageo-datasheet-62067350.pdf?src-supplier=Farnell; ComponentLink7URL: https://datasheet.octopart.com:443/RC0603JR-070RL-Yageo-datasheet-62067350.pdf?src-supplier=Farnell; ComponentLink8Description: https://datasheet.octopart.com:443/RC0603JR-070RL-Yageo-datasheet-15599030.pdf?src-supplier=Future+Electronics; ComponentLink8URL: https://datasheet.octopart.com:443/RC0603JR-070RL-Yageo-datasheet-15599030.pdf?src-supplier=Future+Electronics; ComponentLink9Description: https://datasheet.octopart.com:443/RC0603JR-070RL-Yageo-Group-datasheet-176638170.pdf?src-supplier=Future+Electronics; ComponentLink9URL: https://datasheet.octopart.com:443/RC0603JR-070RL-Yageo-Group-datasheet-176638170.pdf?src-supplier=Future+Electronics; ComponentLink10Description: https://datasheet.octopart.com:443/RC0603JR-070RL-Yageo-datasheet-164759695.pdf?src-supplier=Future+Electronics; ComponentLink10URL: https://datasheet.octopart.com:443/RC0603JR-070RL-Yageo-datasheet-164759695.pdf?src-supplier=Future+Electronics; ComponentLink11Description: https://datasheet.octopart.com:443/RC0603JR-070RL-Yageo-datasheet-137700087.pdf?src-supplier=Future+Electronics; ComponentLink11URL: https://datasheet.octopart.com:443/RC0603JR-070RL-Yageo-datasheet-137700087.pdf?src-supplier=Future+Electronics; ComponentLink12Description: https://datasheet.octopart.com:443/RC0603JR-070RL-Yageo-datasheet-27584510.pdf?src-supplier=Future+Electronics; ComponentLink12URL: https://datasheet.octopart.com:443/RC0603JR-070RL-Yageo-datasheet-27584510.pdf?src-supplier=Future+Electronics; ComponentLink13Description: https://datasheet.octopart.com:443/RC0603JR-070RL-Yageo-datasheet-503470.pdf?src-supplier=Future+Electronics; ComponentLink13URL: https://datasheet.octopart.com:443/RC0603JR-070RL-Yageo-datasheet-503470.pdf?src-supplier=Future+Electronics; ComponentLink14Description: https://datasheet.octopart.com:443/RC0603JR-070RL-Yageo-datasheet-79472498.pdf?src-supplier=Megastar; ComponentLink14URL: https://datasheet.octopart.com:443/RC0603JR-070RL-Yageo-datasheet-79472498.pdf?src-supplier=Megastar; ComponentLink15Description: https://datasheet.octopart.com/RC0603JR-070RL-Yageo-datasheet-73766026.pdf; ComponentLink15URL: https://datasheet.octopart.com/RC0603JR-070RL-Yageo-datasheet-73766026.pdf; ComponentLink16Description: https://datasheet.octopart.com:443/RC0603JR-070RL-Yageo-datasheet-5393049.pdf?src-supplier=element14; ComponentLink16URL: https://datasheet.octopart.com:443/RC0603JR-070RL-Yageo-datasheet-5393049.pdf?src-supplier=element14; ComponentLink17Description: https://datasheet.octopart.com:443/RC0603JR-070RL-Yageo-datasheet-118562.pdf?src-supplier=DigiKey; ComponentLink17URL: https://datasheet.octopart.com:443/RC0603JR-070RL-Yageo-datasheet-118562.pdf?src-supplier=DigiKey; ComponentLink18Description: http://sigma.octopart.com:80/conflict_mineral_statement/Yageo-company-3.pdf?src-supplier=Compliance+Statements; ComponentLink18URL: http://sigma.octopart.com:80/conflict_mineral_statement/Yageo-company-3.pdf?src-supplier=Compliance+Statements; ComponentLink19Description: http://sigma.octopart.com:80/rohs_statement/Yageo-company-2.pdf?src-supplier=Compliance+Statements; ComponentLink19URL: http://sigma.octopart.com:80/rohs_statement/Yageo-company-2.pdf?src-supplier=Compliance+Statements; Composition: Thick Film; Contact Plating: Tin; Country of Origin: Mainland China, Taiwan; Depth: 800µm; Export Control Classification Number (ECCN) Code: EAR99; Harmonized Tariff Schedule (HTS) Code: 8533.21.00.30; Height: 450µm; Introduction Date: 2001-06-08; Lead Free: Lead Free; Length: 1.6mm; Library Name: Passives.SchLib; Lifecycle Status: Production; Max Operating Temperature: 155°C; Military Standard: Not; Min Operating Temperature: -55°C; Mounting Technology: Surface Mount; Number of Terminals: 2; Packaging: Cut Tape; Pins: 2; Pricing: 5000=0.00139, 10000=0.00135, 20000=0.00131, 40000=0.00129, 80000=0.00126 (USD); Radiation Hardening: No; REACH SVHC: Yes; RoHS: Compliant; Stock: 1835000; Supplier 1: Avnet; Supplier Part Number 1: RC0603JR-070RL; Termination: SMD/SMT; Value: 0R; Voltage Rating (DC): 50V; Width: 800µm
